# Altium schematic sheet FinalBoard.SchDoc
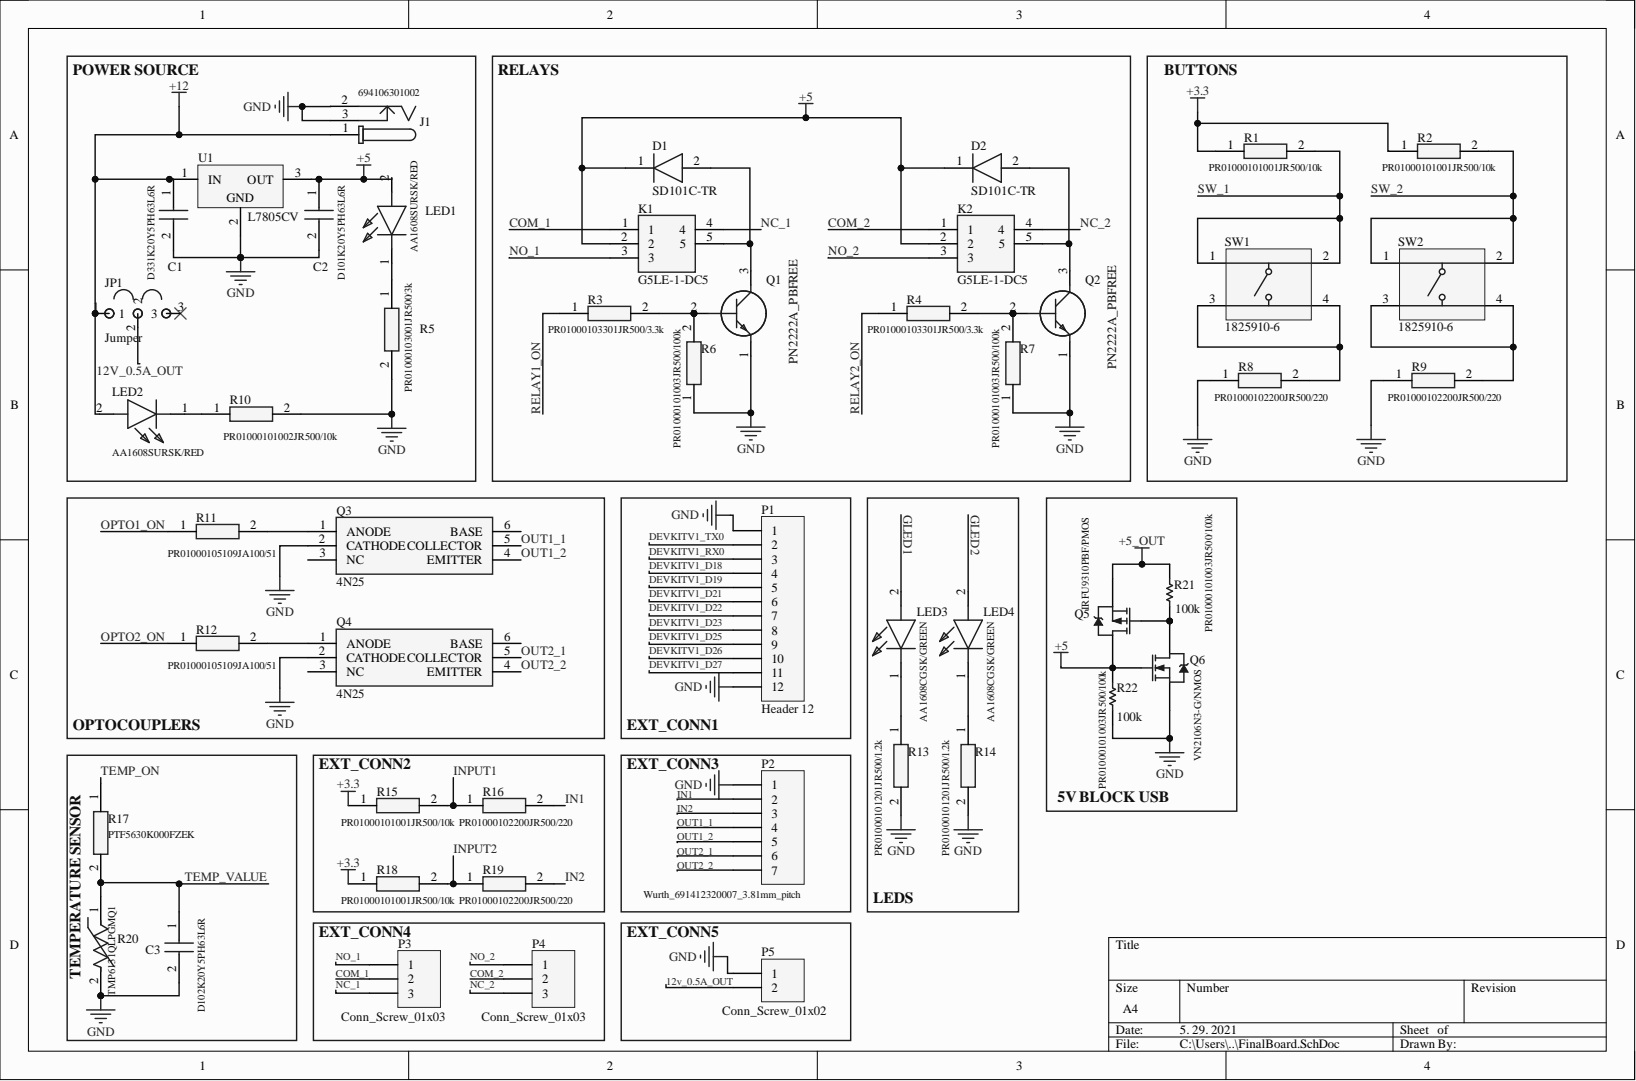
[diagram p0: FinalBoard.SchDoc]

## Parts
C1  D331K20Y5PH63L6R  [Ceramic_Capacitor_Vishay_6mm]
C2  D101K20Y5PH63L6R  [Ceramic_Capacitor_Vishay_6mm]
C3  D102K20Y5PH63L6R  [Ceramic_Capacitor_Vishay_6mm]
D1  SD101C-TR  [DIOAD950W60L350D150]
D2  SD101C-TR  [DIOAD950W60L350D150]
J1  694106301002  [694106301002]
JP1  Jumper  [HDR1X3]
K1  G5LE-1-DC5  [G5LE-1]
K2  G5LE-1-DC5  [G5LE-1]
LED1  AA1608SURSK/RED  [AA1608SURSK]
LED2  AA1608SURSK/RED  [AA1608SURSK]
LED3  AA1608CGSK/GREEN  [AA1608CGSK]
LED4  AA1608CGSK/GREEN  [AA1608CGSK]
P1  Header 12  [HDR1X12]
P2  Wurth_691412320007_3.81mm_pitch  [Wurth_691412320007_3.81mm_pitch]
P3  Conn_Screw_01x03  [Wurth_691322310003_3.81mm_pitch]
P4  Conn_Screw_01x03  [Wurth_691322310003_3.81mm_pitch]
P5  Conn_Screw_01x02  [Wurth_691322310002_3.81mm_pitch]
Q1  PN2222A_PBFREE  [TO-92A]
Q2  PN2222A_PBFREE  [TO-92A]
Q3  4N25  [DIP762W60P254L730H450Q6N]
Q4  4N25  [DIP762W60P254L730H450Q6N]
Q5  IRFU9310PBF/PMOS  [TO229P239X654X978-3P]
Q6  VN2106N3-G/NMOS  [TO-92]
R1  PR01000101001JR500/10k  [axial-0.3]
R2  PR01000101001JR500/10k  [axial-0.3]
R3  PR01000103301JR500/3.3k  [axial-0.3]
R4  PR01000103301JR500/3.3k  [axial-0.3]
R5  PR01000103001JR500/3k  [axial-0.3]
R6  PR01000101003JR500/100k  [axial-0.3]
R7  PR01000101003JR500/100k  [axial-0.3]
R8  PR01000102200JR500/220  [axial-0.3]
R9  PR01000102200JR500/220  [axial-0.3]
R10  PR01000101002JR500/10k  [axial-0.3]
R11  PR01000105109JA100/51  [axial-0.3]
R12  PR01000105109JA100/51  [axial-0.3]
R13  PR01000101201JR500/1.2k  [axial-0.3]
R14  PR01000101201JR500/1.2k  [axial-0.3]
R15  PR01000101001JR500/10k  [axial-0.3]
R16  PR01000102200JR500/220  [axial-0.3]
R17  PTF5630K000FZEK  [AXIAL-0.3]
R18  PR01000101001JR500/10k  [axial-0.3]
R19  PR01000102200JR500/220  [axial-0.3]
R20  TMP6131QLPGMQ1  [FP-LPG0002A-MFG]
R21  PR01000101003JR500/100k  value 100k  [AXIAL-0.3]
R22  PR01000101003JR500/100k  value 100k  [AXIAL-0.3]
SW1  1825910-6  [TECO-1825910-6_V]
SW2  1825910-6  [TECO-1825910-6_V]
U1  L7805CV  [TO220]

## Nets
+3.3: R1.1 R2.1 R15.1 R18.1
+5: C2.1 D1.1 D2.1 K1.2 K2.2 LED1.2 Q5.2 Q6.1 R22.2 U1.3
+5_OUT: Q5.3 R21.2
GND: C1.2 C2.2 C3.2 J1.2 J1.3 P1.1 P1.12 P2.1 P5.1 Q1.1 Q2.1 Q3.2(CATHODE) Q4.2(CATHODE) Q6.3 R5.2 R6.1 R7.1 R8.1 R9.1 R10.2 R13.2 R14.2 R20.2 R22.1 U1.2
12v_0.5A_OUT: C1.1 J1.1 JP1.1 JP1.2 LED2.2 P5.2 U1.1
COM_1: K1.1 P3.2
COM_2: K2.1 P4.2
DEVKITV1_D18: P1.4
DEVKITV1_D19: P1.5
DEVKITV1_D21: P1.6
DEVKITV1_D22: P1.7
DEVKITV1_D23: P1.8
DEVKITV1_D25: P1.9
DEVKITV1_D26: P1.10
DEVKITV1_D27: P1.11
DEVKITV1_RX0: P1.3
DEVKITV1_TX0: P1.2
GLED1: LED3.2
GLED2: LED4.2
IN1: P2.2 R16.2
IN2: P2.3 R19.2
INPUT1: R15.2 R16.1
INPUT2: R18.2 R19.1
NC_1: K1.4 P3.3
NC_2: K2.4 P4.3
NO_1: K1.3 P3.1
NO_2: K2.3 P4.1
OPTO1_ON: R11.1
OPTO2_ON: R12.1
OUT1_1: P2.4 Q3.5(COLLECTOR)
OUT1_2: P2.5 Q3.4(EMITTER)
OUT2_1: P2.6 Q4.5(COLLECTOR)
OUT2_2: P2.7 Q4.4(EMITTER)
RELAY1_ON: R3.1
RELAY2_ON: R4.1
SW_1: R1.2 SW1.1 SW1.2
SW_2: R2.2 SW2.1 SW2.2
TEMP_ON: R17.1
TEMP_VALUE: C3.1 R17.2 R20.1
NetD1_2: D1.2 K1.5 Q1.3
NetD2_2: D2.2 K2.5 Q2.3
NetLED1_1: LED1.1 R5.1
NetLED2_1: LED2.1 R10.1
NetLED3_1: LED3.1 R13.1
NetLED4_1: LED4.1 R14.1
NetQ1_2: Q1.2 R3.2 R6.2
NetQ2_2: Q2.2 R4.2 R7.2
NetQ3_1: Q3.1(ANODE) R11.2
NetQ4_1: Q4.1(ANODE) R12.2
NetQ5_1: Q5.1 Q6.2 R21.1
NetR8_2: R8.2 SW1.3 SW1.4
NetR9_2: R9.2 SW2.3 SW2.4

Not connected: JP1 3; Q3 3(NC) 6(BASE); Q4 3(NC) 6(BASE)

## Library data of the parts
C1 = D331K20Y5PH63L6R: Capacitor; Manufacturer_Name: Vishay; Component Kind: Standard; Manufacturer_Part_Number: D331K20Y5PH63L6R
C2 C3 = D101K20Y5PH63L6R: Capacitor; Manufacturer_Name: Vishay; Arrow Part Number: D101K20Y5PH63L6R; Arrow Price/Stock: https://www.arrow.com/en/products/d101k20y5ph63l6r/vishay; Component Kind: Standard; Datasheet Link: https://www.vishay.com/docs/28549/dseries.pdf; Manufacturer_Part_Number: D101K20Y5PH63L6R; Mouser Part Number: 594-D101K20Y5PH63L6R; Mouser Price/Stock: https://www.mouser.co.uk/ProductDetail/Vishay-BC-Components/D101K20Y5PH63L6R/?qs=wEmTtUuRSe5Ir%2FEf6sSU5g%3D%3D
D1 D2 = SD101C-TR: Diode; Manufacturer_Name: Vishay; Component Kind: Standard; Datasheet Link: https://www.arrow.com/en/products/sd101c-tr/vishay; Library Name: SamacSys.SchLib; Manufacturer_Part_Number: SD101C-TR
J1 = CMP-1502-00188-1: DC Power Jack Connector WR-DC, Male, Right Angled, THT, 5 A, 24 V; Manufacturer: Wurth Elektronik; Opening Diameter: 6.4mm; Plug Inner Diameter: 2.05mm; Plug Outer Diameter: 5.5mm; Rated Current: 5A; Working Voltage: 24V; Component Kind: Standard; Datasheet URL: http://katalog.we-online.de/em/datasheet/6941xx301002.pdf; Gender: Male; Library Name: Altium Content Vault; Manufacturer URL: http://www.we-online.de; Mounting Technology: THT; Operating Temperature: -40to85degC; Orientation: RightAngled; Package Reference: 694106301002; Packaging: Bag; Part Number: 694106301002; Publisher: WurthElektronik
K1 K2 = G5LE-1-DC5: Relay or Contactor; Manufacturer_Name: Omron Electronics; Component Kind: Standard; Datasheet Link: http://www.mouser.com/ds/2/307/G5LE_0813-267607.pdf; Manufacturer_Part_Number: G5LE-1-DC5; Mouser Part Number: 653-G5LE-1-DC5; Mouser Price/Stock: https://www.mouser.co.uk/ProductDetail/Omron-Electronics/G5LE-1-DC5?qs=ImaqFqjHA4nKN7ETIjn3Lg%3D%3D
LED1 = AA1608SURSK: LED; Manufacturer_Name: Kingbright; Component Kind: Standard; Datasheet Link: https://www.mouser.com/datasheet/2/216/AA1608SURSK-1951973.pdf; Height: 0.7mm; Manufacturer_Part_Number: AA1608SURSK; Mouser Part Number: 604-AA1608SURSK; Mouser Price/Stock: https://www.mouser.co.uk/ProductDetail/Kingbright/AA1608SURSK?qs=eP2BKZSCXI6pqonvuMmTFg%3D%3D
LED2 = AA1608SURSK: LED green; Manufacturer_Name: Kingbright; Component Kind: Standard; Datasheet Link: https://www.mouser.com/datasheet/2/216/AA1608SURSK-1951973.pdf; Height: 0.7mm; Manufacturer_Part_Number: AA1608SURSK; Mouser Part Number: 604-AA1608SURSK; Mouser Price/Stock: https://www.mouser.co.uk/ProductDetail/Kingbright/AA1608SURSK?qs=eP2BKZSCXI6pqonvuMmTFg%3D%3D
LED3 LED4 = AA1608CGSK: LED; Manufacturer_Name: Kingbright; Component Kind: Standard; Datasheet Link: https://au.mouser.com/datasheet/2/216/AA1608CGSK-1951957.pdf; Height: 0.65mm; Manufacturer_Part_Number: AA1608CGSK; Mouser Part Number: 604-AA1608CGSK; Mouser Price/Stock: https://www.mouser.co.uk/ProductDetail/Kingbright/AA1608CGSK?qs=eP2BKZSCXI5BYF2DjL8nRw%3D%3D
P1 = Header 12: Header, 12-Pin; Component Kind: Standard; LatestRevisionDate: 17-Jul-2002; LatestRevisionNote: Re-released for DXP Platform.; Library Name: Miscellaneous Connectors.IntLib; Signal Integrity: Connector; Publisher: Altium Limited
P2 = MHDR1X7: Header, 7-Pin; Component Kind: Standard; LatestRevisionDate: 17-Jul-2002; LatestRevisionNote: Re-released for DXP Platform.; Library Name: Miscellaneous Connectors.IntLib; Signal Integrity: Connector; Publisher: Altium Limited
P3 P4 = MHDR1X3: Header, 3-Pin; Component Kind: Standard; LatestRevisionDate: 17-Jul-2002; LatestRevisionNote: Re-released for DXP Platform.; Library Name: Miscellaneous Connectors.IntLib; Signal Integrity: Connector; Publisher: Altium Limited
P5 = MHDR1X2: Header, 2-Pin; Component Kind: Standard; LatestRevisionDate: 17-Jul-2002; LatestRevisionNote: Re-released for DXP Platform.; Library Name: Miscellaneous Connectors.IntLib; Signal Integrity: Connector; Publisher: Altium Limited
Q1 Q2 = PN2222A_PBFREE: Transistor BJT NPN; Manufacturer_Name: Central Semiconductor; Manufacturer_Part_Number: PN2222A PBFREE; Component Kind: Standard; Datasheet Link: https://my.centralsemi.com/datasheets/PN2221-2222A.PDF; Height: 4.19mm
Q3 Q4 = 4N25: Transistor; Manufacturer_Name: Lite-On; Arrow Part Number: 4N25; Arrow Price/Stock: https://www.arrow.com/en/products/4n25/lite-on-technology; Component Kind: Standard; Datasheet Link: http://optoelectronics.liteon.com/upload/download/DS-70-99-0010/S_110_4N25(26).pdf; Height: 4.5mm; Manufacturer_Part_Number: 4N25; Mouser Part Number: 859-4N25; Mouser Price/Stock: https://www.mouser.co.uk/ProductDetail/Lite-On/4N25?qs=gnaPJ2cis70RM9udB%2FP83w%3D%3D
Q5 = PMOS-2: P-Channel Power MOSFET; Note: Pin 4 not drawn and electrically connected to Pin 2.; Component Kind: Standard; ComponentLink1Description: Manufacturer Link; ComponentLink1URL: http://www.irf.com/; DatasheetVersion: PD-9.390D; LatestRevisionDate: 17-Jul-2002; LatestRevisionNote: Re-released for DXP Platform.; Library Name: Miscellaneous Devices.IntLib; PackageDescription: TO, Thru-Hole, Vertical, Heatsink Mounted; 3 In-Line Leads; Pitch 2.54 mm; PackageReference: TO-220AB; PackageVersion: Feb-1997; Published: 8-Jun-2000; Simulation: IRF9510; Publisher: Altium Limited
Q6 = NMOS-2: N-Channel Power MOSFET; Note: Pin 2 is electrically connected to pin 4.; Component Kind: Standard; ComponentLink1Description: Manufacturer Link; ComponentLink1URL: http://www.irf.com/; DatasheetVersion: PD-9.814; LatestRevisionDate: 17-Jul-2002; LatestRevisionNote: Re-released for DXP Platform.; Library Name: Miscellaneous Devices.IntLib; PackageDescription: TO, Thru-Hole, Vertical, Heatsink Mounted; 3 In-Line Leads; Pitch 2.54 mm; PackageReference: TO-220AB; PackageVersion: Feb-1997; Published: 8-Jun-2000; Simulation: IRF1010; Publisher: Altium Limited
R1-R4 R6-R9 R13-R16 R18 R19 = PR01000103301JR500: Resistor; Manufacturer_Name: Vishay; Component Kind: Standard; Datasheet Link: http://www.farnell.com/datasheets/2049118.pdf; Manufacturer_Part_Number: PR01000103301JR500
R5 R10-R12 = PR01000101002JR500: Resistor; Manufacturer_Name: Vishay; Component Kind: Standard; Datasheet Link: https://www.vishay.com/docs/28729/pr010203.pdf; Manufacturer_Part_Number: PR01000101002JR500; Mouser Part Number: 594-5073NW10K00J; Mouser Price/Stock: https://www.mouser.co.uk/ProductDetail/Vishay-BC-Components/PR01000101002JR500?qs=LCMWAU1DZcxQDhZuaUJ9sg%3D%3D
R17 = PTF6530K000AYEK: Resistor; Manufacturer_Name: Vishay; Component Kind: Standard; Datasheet Link: https://eu.mouser.com/datasheet/2/427/ptf-1763051.pdf; Manufacturer_Part_Number: PTF6530K000AYEK
R20 = CMP-04816-000001-1: SENSOR PTC 10K OHM 1% TO92S; Manufacturer: Texas Instruments; Automotive Grade: Grade 1; Max Junction Temp: +150°C; Resistance: 10kΩ; Temperature Coefficient: 6400ppm/°C; Value: 10kΩ; Voltage Rating: 5.5V; Ambient Temperature Range High: +125°C; Ambient Temperature Range Low: -40°C; Automotive: Yes; Category: Res; Component Kind: Standard; ComponentLink2Description: Datasheet; ComponentLink2URL: http://www.ti.com/lit/ds/symlink/tmp61-q1.pdf; Composition: Silicon; Device Class L1: Passive Components; Device Class L2: Resistors; Device Class L3: Thermistors; Digikey Description: SENSOR PTC 10K OHM 1% TO92S; Height: 5.05mm; Lead Free: Yes; Library Name: Altium Content Vault; Manufacturer Part Number: TMP6131QLPGMQ1; Octopart: https://octopart.com/tmp6131qlpgmq1-texas+instruments-100902490; Package: LPG0002A; RoHS: TRUE; Series: TMP61-Q1; Temperature: -40°C to +125°C; Temperature Range High: +125°C; Temperature Range Low: -40°C; Tolerance: 1%
R21 R22 = Res1: Resistor; Component Kind: Standard; LatestRevisionDate: 17-Jul-2002; LatestRevisionNote: Re-released for DXP Platform.; Library Name: Miscellaneous Devices.IntLib; PackageDescription: Axial Device, Thru-Hole; 2 Leads; 0.3 in Pin Spacing; PackageReference: AXIAL-0.3; Published: 8-Jun-2000; Signal Integrity: Res; Simulation: RESISTOR; Publisher: Altium Limited
SW1 SW2 = CMP-1684-00021-1: Tact Switch, SPST-NO, 0.05 A, -35 to 85 degC, 4-Pin THD, RoHS, Bulk; Manufacturer: TE Connectivity; Actuator Color: Black; Actuator Length: 1.4mm; Actuator Material: PolybutyleneTerephthalate; Actuator Style: RoundButton; Body Orientation: Straight; Bushing Type: Unthreaded; Contact Rating @ Voltage: 0.05A@24VDC; Dielectric Strength: 500VAC; Electrical Life: 100000Cycles; Function: MomentaryContact; Illumination: N/R; Maximum Contact Resistance: 100mOhm; Maximum DC Voltage Rating: 24V; Pole Throw Configuration: SPST; Switch Configuration: OFF(ON); Base Material: N/A; Component Kind: Standard; Contact Material: CopperAlloy; Contact Plating: Silver; Datasheet URL: http://www.te.com/commerce/DocumentDelivery/DDEController?Action=showdoc&DocId=Customer+Drawing%7F1825910%7FC9%7Fpdf%7FEnglish%7FENG_CD_1825910_C9.pdf%7F1825910-6; Datasheet Version: Rev. C9; Dimension: 5.99x5mm; Family: 1825910; Housing Material: N/A; Library Name: Altium Content Vault; Manufacturer URL: http://www.te.com; Maximum AC Voltage Rating: N/A; Mechanical Life: 100000Cycles; Minimum Insulation Resistance: 100MOhm; Mounting: ThroughHole; Operating Temperature: -35to85C; Package Description: 4-Pin Through Hole Device, Body 5.99 x 5.99 mm, Pitch 6.5 mm; Package Reference: THD; Packaging: N/A; Part No: 1825910-6; Product Weight: N/A; RoHS: Yes; Seal Description: N/A; Seal Location: N/A; Terminal Type: PCPins; Thread Size: N/R
U1 = CMP-0244-00483-1: Positive Voltage Regulator, 5V, 3-Pin TO-220; Component Kind: Standard; ComponentLink1Description: Manufacturer URL; ComponentLink1URL: http://www.st.com; ComponentLink2Description: Datasheet; ComponentLink2URL: http://www.st.com/internet/com/TECHNICAL_RESOURCES/TECHNICAL_LITERATURE/DATASHEET/CD00000444.pdf; ComponentLink3Description: Package Specification; ComponentLink3URL: http://www.st.com/internet/com/TECHNICAL_RESOURCES/TECHNICAL_LITERATURE/PACKAGE_INFORMATION/CD00004649.pdf; DatasheetVersion: 16/11/2011; Library Name: Altium Content Vault; PackageDescription: TO, Thru-Hole, Vertical, Heatsink Mounted; 3 In-Line Leads; Pitch 2.54 mm; PackageReference: TO220; PackageVersion: ver3.0
JP1 = Jumper: Component Kind: Standard; Library Name: FinalBoard.SchLib
